# Altium schematic sheet lv_FANS.SchDoc
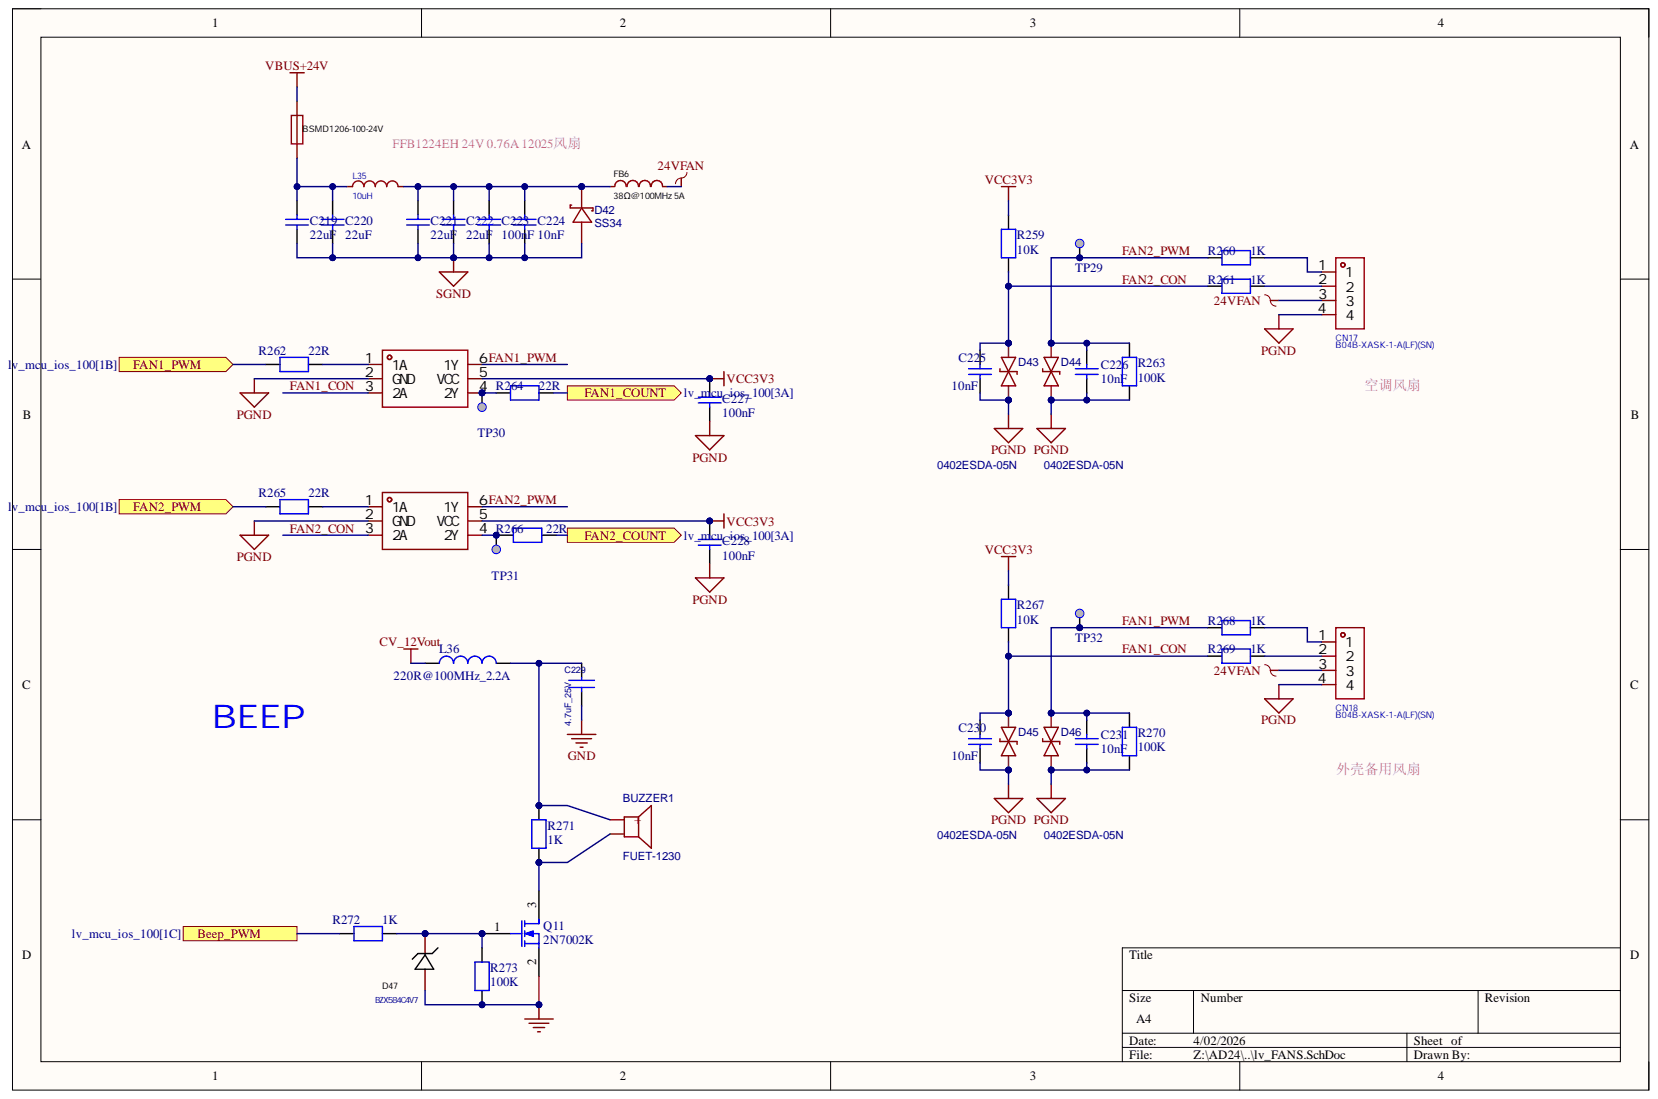
[diagram p0: lv_FANS.SchDoc]

## Parts
BUZZER1  FUET-1230  [BUZ-SMD_L12.0-W12.0-P11.6]
C219  22uF  [C1206]
C220  22uF  [C1206]
C221  22uF  [C1206]
C222  22uF  [C1206]
C223  100nF  [C0402]
C224  10nF  [C0402]
C225  10nF  [C0402]
C226  10nF  [C0402]
C227  100nF  [C0402]
C228  100nF  [C0402]
C229  4.7uF_25V  [C0603]
C230  10nF  [C0402]
C231  10nF  [C0402]
CN17  B04B-XASK-1-A(LF)(SN)  [CONN-TH_B04B-XASK-1-A-LF-SN]
CN18  B04B-XASK-1-A(LF)(SN)  [CONN-TH_B04B-XASK-1-A-LF-SN]
D42  SS34  [DO-214AC_L4.3-W2.7-LS5.3-RD]
D43  0402ESDA-05N  [D0402-BI]
D44  0402ESDA-05N  [D0402-BI]
D45  0402ESDA-05N  [D0402-BI]
D46  0402ESDA-05N  [D0402-BI]
D47  BZX584C4V7  [SOD-523F_L1.2-W0.8-LS1.7-RD]
F6  BSMD1206-100-24V  [1206]
FB6  LCSC Part Name: 38Ω@100MHz 5A  [L1206]  (hidden comment: PZ3216D380-5R0TF)
L35  10uH  [IND-SMD_L3.0-W3.0_FTC303020D]
L36  220R@100MHz_2.2A  [L0603]
Q11  2N7002K  [SOT-23(SOT-23-3)]
R259  10K  [R0603]
R260  1K  [R0603]
R261  1K  [R0603]
R262  22R  [R0402]
R263  100K  [R0603]
R264  22R  [R0402]
R265  22R  [R0402]
R266  22R  [R0402]
R267  10K  [R0603]
R268  1K  [R0603]
R269  1K  [R0603]
R270  100K  [R0603]
R271  1K  [R0603]
R272  1K  [R0402]
R273  100K  [R0402]
TP29  Test Point  [TP_SMD_1MM]  (value not drawn)  (no BOM)
TP30  Test Point  [TP_SMD_1MM]  (value not drawn)  (no BOM)
TP31  Test Point  [TP_SMD_1MM]  (value not drawn)  (no BOM)
TP32  Test Point  [TP_SMD_1MM]  (value not drawn)  (no BOM)
U56  74LVC2G17GW,125  [SOT-363_L2.0-W1.3-P0.65-LS2.1-BR]  (value not drawn)
U57  74LVC2G17GW,125  [SOT-363_L2.0-W1.3-P0.65-LS2.1-BR]  (value not drawn)

## Ports
Beep_PWM, FAN1_COUNT, FAN1_PWM, FAN2_COUNT, FAN2_PWM

## Nets
24VFAN: CN17.3 CN18.3 FB6.1
CV_12Vout: L36.2
GND: C229.2 D47.2 Q11.2 R273.1
PGND: C225.1 C226.1 C227.1 C228.1 C230.1 C231.1 CN17.4 CN18.4 D43.1 D44.1 D45.1 D46.1 R263.1 R270.1 U56.2(GND) U57.2(GND)
SGND: C219.1 C220.1 C221.1 C222.1 C223.1 C224.1 D42.2
VBUS+24V: F6.2
VCC3V3: C227.2 C228.2 R259.2 R267.2 U56.5(VCC) U57.5(VCC)
Beep_PWM: R272.1 ; port Beep_PWM
FAN1_CON: C230.2 D45.2 R267.1 R269.2 U56.3(2A)
FAN1_COUNT: R264.2 ; port FAN1_COUNT
FAN1_PWM: C231.2 D46.2 R268.2 R270.2 TP32.1 U56.6(1Y)
FAN2_CON: C225.2 D43.2 R259.1 R261.2 U57.3(2A)
FAN2_COUNT: R266.1 ; port FAN2_COUNT
FAN2_PWM: C226.2 D44.2 R260.2 R263.2 TP29.1 U57.6(1Y)
NetBUZZER1_1: BUZZER1.1 C229.1 L36.1 R271.2
NetBUZZER1_2: BUZZER1.2 Q11.3 R271.1
NetC219_2: C219.2 C220.2 F6.1 L35.2
NetC221_2: C221.2 C222.2 C223.2 C224.2 D42.1 FB6.2 L35.1
NetCN17_1: CN17.1 R260.1
NetCN17_2: CN17.2 R261.1
NetCN18_1: CN18.1 R268.1
NetCN18_2: CN18.2 R269.1
NetD47_1: D47.1 Q11.1 R272.2 R273.2
NetR262_1: R262.1 ; port FAN1_PWM
NetR262_2: R262.2 U56.1(1A)
NetR264_1: R264.1 TP30.1 U56.4(2Y)
NetR265_1: R265.1 ; port FAN2_PWM
NetR265_2: R265.2 U57.1(1A)
NetR266_2: R266.2 TP31.1 U57.4(2Y)

## Library data of the parts
BUZZER1 = FST-1230: buzzer; BOM_Manufacturer: FUET; Contributor: LCSC; Frequency: 4000Hz; BOM_JLCPCB Part Class: Extended Part; BOM_Manufacturer Part: FST-1230; BOM_Supplier: LCSC; BOM_Supplier Part: C391037; Component Kind: Standard; Library Name: HiPin_Library_AD.IntLib; spicePre: B; spiceSymbolName: FST-1230
C219-C222 = Capacitor_2: 35V, X5R, 10%; Manufacturer: TDK; Manufacturer_Part_Number: C3216X5R1V226MTJ00E; Component Kind: Standard; ComponentLink1Description: Datasheet; ComponentLink1URL: http://tunmcdsvn.tun.st.com/Component_Datasheet_Library/Trunk/Capacitors/Yageo_Multilayer_capacitor_X5R_series_100pF_to_100uF; Library Name: HiPin_Library_AD.IntLib
C223-C228 C230 C231 = Capacitor: 50V, 10%, X7R; Internal_Part_Number: MCD-00003; Manufacturer: muRata; Manufacturer_Part_Number: GRM155R71H104KE14D; Component Kind: Standard; ComponentLink1Description: Datasheet; ComponentLink1URL: http://tunmcdsvn.tun.st.com/Component_Datasheet_Library/Trunk/Capacitors/Yageo_Capacitor_NP0_16V-to-50V; Library Name: HiPin_Library_AD.IntLib; Package: C0402
  C223 C227 C228: Value: 100nF
  C224-C226 C230 C231: Value: 10nF
C229 = CAP NP: X5R, 10%; Manufacturer: muRata; Manufacturer_Part_Number: GRM188R61E475KE11D; Component Kind: Standard
CN17 CN18 = B04B-XASK-1-A(LF)(SN): 插座XA2.5mm,3A,H8.8mm; Manufacturer: JST; Add into BOM: yes; Flame Retardant Rating: UL94V-0; Number of PINs Per Row: 4; Pins Structure: 1x4P; Pitch: 2.5mm; Plastic Material: 玻纤增强PA66; Rated Voltage (Max): 250V; Reference Series: XA; Supplementary Features: 带柱；打K脚; X-Length of Bottom Edge on Board (Spacing Line): 12.5mm; Y-Width of Bottom Edge on Board: 6.4mm; Z-Height of the Board: 8.8mm; Colour: 白色; Component Kind: Standard; Contact Material: 铜合金; Contact Plating: 锡; Convert to PCB: yes; Current Rating (Max): 3A; Datasheet: https://atta.szlcsc.com/upload/public/pdf/source/20200416/C514162_2DCD7D1CD2019D14145B8FEA1B6236C2.pdf; Device: B04B-XASK-1-A(LF)(SN); JLCPCB Part Class: Extended Part; LCSC Part Name: 1x4P 间距:2.5mm 直插 系列:XA; Library Name: HiPin_Library_AD.IntLib; Manufacturer Part: B04B-XASK-1-A(LF)(SN); Mounting Style: 直插; Number of Pins: 4P; Number of Rows: 1; Operating Temperature Range: -25℃~+85℃; PCB3D: CONN-TH_B04B-XASK-1-A-LF-SN; Row Spacing: -; Supplier: LCSC; Supplier Footprint: 插件,P=2.5mm; Supplier Part: C491709; Symbol: B04B-XASK-1-A(LF)(SN)
D42 = SS34_C8678: BOM_Manufacturer: MDD; BOM_JLCPCB Part Class: Basic Part; BOM_Manufacturer Part: SS34; BOM_Supplier: LCSC; BOM_Supplier Part: C8678; Component Kind: Standard; Library Name: HiPin_Library_AD.IntLib; spicePre: D; spiceSymbolName: SS34_C8678
D43-D46 = 0402ESDA-05N: BOM_Manufacturer: Bourne Semicon (Shenzhen); Contributor: LCSC; BOM_JLCPCB Part Class: Extended Part; BOM_Manufacturer Part: 0402ESDA-05N; BOM_Supplier: LCSC; BOM_Supplier Part: C316049; Component Kind: Standard; Library Name: HiPin_Library_AD.IntLib; spicePre: D; spiceSymbolName: 0402ESDA-05N
D47 = BZT52C4V7S: Manufacturer: CJ(江苏长电/长晶); Add into BOM: yes; Diode Configuration: 独立式; Power Dissipation: 500mW; Reverse Leakage Current (Ir): 3uA@4V; Zener Impedance (Zzt): 10Ω; Zener Voltage (Nom): 6.2V; Zener Voltage (Range): 5.8V~6.6V; Component Kind: Standard; Convert to PCB: yes; Datasheet: https://atta.szlcsc.com/upload/public/pdf/source/20211105/0A7AF84D57D732370551C8DD7A10F563.pdf; JLCPCB Part Class: Extended Part; Library Name: HiPin_Library_AD.IntLib; Manufacturer Part: BZX584C4V7; Name: BZX584C4V7; Supplier: LCSC; Supplier Footprint: SOD-123; Tolerance: -
F6 = 2920L260/24DR: 耐压: 24V  最大电流: 40A  保持电流(Ih): 1A  跳闸电流: 1.8A; Manufacturer: HFUSE(佰宏); Component Kind: Standard; Library Name: HiPin_Library_AD.IntLib; Manufacturer Part: BSMD1206-100-24V
FB6 = BLM31PG601SN1L: Manufacturer: Sunlord(顺络); Add into BOM: yes; Circuits: 1; Current Rating: 1.5A; DC Resistance: 80mΩ; Impedance @ Frequency: 600Ω@100MHz; Tolerance: ±25%; Component Kind: Standard; Convert to PCB: yes; Datasheet: https://atta.szlcsc.com/upload/public/pdf/source/20180326/C88997_FE7208E239BA92CF7577D85CF2703D30.pdf; Device: BLM31PG601SN1L; JLCPCB Part Class: Extended Part; Library Name: HiPin_Library_AD.IntLib; Manufacturer Part: PZ3216D380-5R0TF; Supplier: LCSC; Supplier Footprint: 1206; Supplier Part: C16902; Symbol: BLM31PG601SN1L
L35 = FTC303020D100MBCA: Manufacturer: cjiang(长江微电); Add into BOM: yes; DC Resistance (DCR): 135mΩ; Rated Current: 2.5A; Saturation Current (Isat): 3.8A; Tolerance: ±20%; Type: 一体成型电感; Component Kind: Standard; Convert to PCB: yes; Datasheet: https://atta.szlcsc.com/upload/public/pdf/source/20230614/D39208D019DF4F1446B96572E3A14872.pdf; Device: FTC303020D100MBCA; JLCPCB Part Class: Extended Part; LCSC Part Name: 10uH ±20% 3.8A; Library Name: HiPin_Library_AD.IntLib; Manufacturer Part: FTC303020D100MBCA; PCB3D: IND-SMD_L3.0-W3.0_FTC303020D; Supplier: LCSC; Supplier Footprint: SMD,3x3mm; Supplier Part: C7423323; Symbol: FTC303020D100MBCA; Value: 10uH
L36 = MCD-00047: SMD Chip ferrite bead, 220R@100MHz +/-25%,2.2A, 50mohm; Manufacturer: Sunlord; Manufacturer_Part_Number: UPZ1608U221-2R2TF; Database Table Name: MCD_PARTS$; Component Kind: Standard; ComponentLink1Description: Datasheet; ComponentLink1URL: http://tunmcdsvn.tun.st.com/Component_Datasheet_Library/Trunk/Inductors/TaiTech_Bead_FCM1608_series; Internal_Part_Number: MCD-00047; Library Name: MCD_PARTS_database.DbLib
Q11 = MOSFET-N: N沟道,60V,0.115A,7Ω@10V; Manufactu: CJ江苏长电; ManufacturerNumber: 2N7002; Component Kind: Standard; Library Name: HiPin_Library_AD.IntLib; Package: SOT-23; SuppliersPartNumber: C8545
R259 R267 = RES: 1%; Component Kind: Standard; Library Name: HiPin_Library_AD.IntLib; Value: 10K
R260-R266 R268-R270 R272 R273 = RES: 1%; Value: 10K; Component Kind: Standard; Library Name: HiPin_Library_AD.IntLib
R271 = RES: Resistor; Component Kind: Standard; Library Name: HiPin_Library_AD.IntLib
TP29-TP32 = MCD-00074: Test Point 1mm; Part_Type: Mechanical; Database Table Name: MCD_PARTS$; ComponentLink1Description: Datasheet; ComponentLink1URL: http://na; ECCN_EU: n/a; ECCN_US: n/a; Internal_Part_Number: MCD-00074; Library Name: MCD_PARTS_database.DbLib; Manufacturer: n/a; Manufacturer_Part_Number: n/a; Provided_By: n/a; Status: Released
U56 U57 = 74LVC2G17GW,125: 2路斯密特触发器; Manufacturer: Nexperia(安世); Add into BOM: yes; Current - Output High(IOH): 32mA; Input type: 施密特触发器; Logic Family: 74LVC; Number of Bits per Element: 1; Number of Elements: 2; output type: 推挽; Voltage - Supply: 1.65V~5.5V; Component Kind: Standard; Convert to PCB: yes; Current - Output Low(IOL): 32mA; Datasheet: https://atta.szlcsc.com/upload/public/pdf/source/20240724/F8BC06F6786231A20F016105A2EDA3EF.pdf; Device: 74LVC2G17GW,125; JLCPCB Part Class: Extended Part; LCSC Part Name: 74LVC2G17GW,125; Library Name: HiPin_Library_AD.IntLib; Manufacturer Part: 74LVC2G17GW,125; Operating Temperature: -40℃~+125℃; PCB3D: SOT-363-6P_L2.0-W1.3-H1.1-LS2.0-P0.65; Supplier: LCSC; Supplier Footprint: SOT-363; Supplier Part: C80500; Symbol: 74LVC2G17GW,125
